# Altium schematic sheet p04_sensors.SchDoc
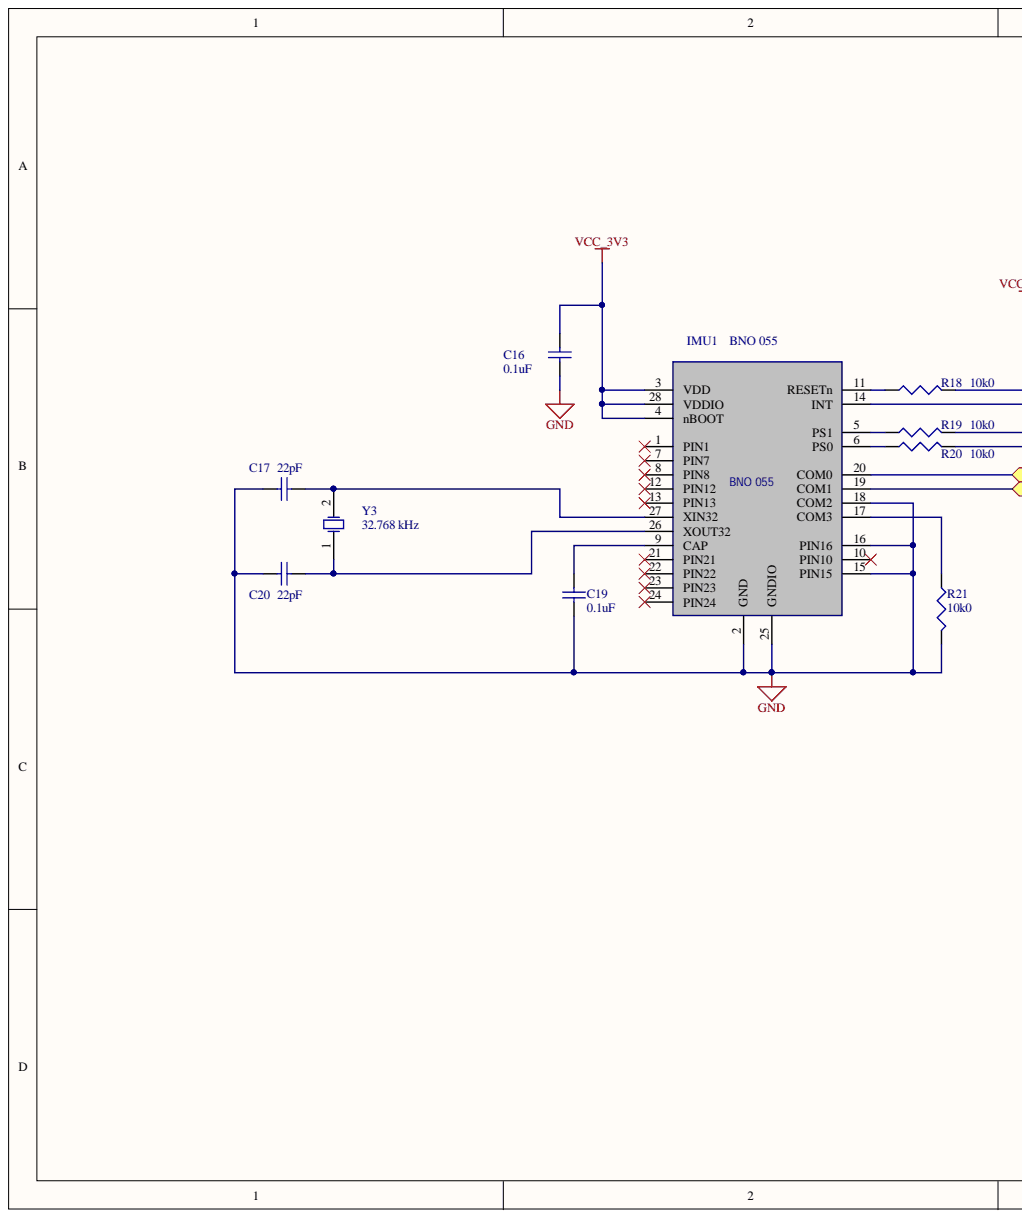
[diagram p0: p04_sensors.SchDoc, left]
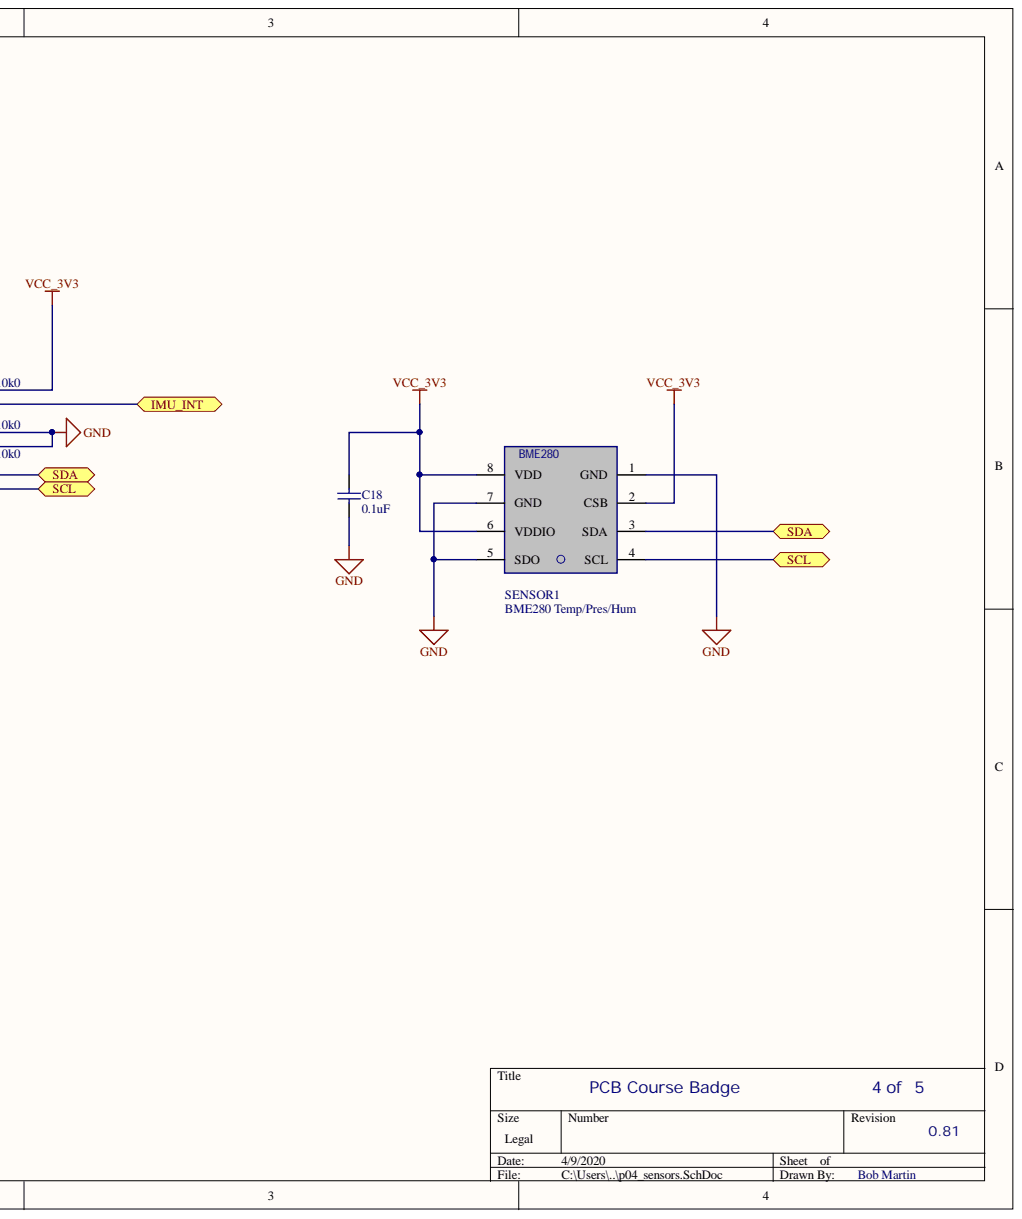
[diagram p1: p04_sensors.SchDoc, right]

## Parts
C16  value 0.1uF  [RAD-0.3]  (hidden comment: Cap)
C17  value 22pF  [RAD-0.3]  (hidden comment: Cap)
C18  value 0.1uF  [RAD-0.3]  (hidden comment: Cap)
C19  value 0.1uF  [RAD-0.3]  (hidden comment: Cap)
C20  value 22pF  [RAD-0.3]  (hidden comment: Cap)
IMU1  BNO 055
R18  value 10k0  [J1-0603]  (hidden comment: Res3)
R19  value 10k0  [J1-0603]  (hidden comment: Res3)
R20  value 10k0  [J1-0603]  (hidden comment: Res3)
R21  value 10k0  [J1-0603]  (hidden comment: Res3)
SENSOR1  BME280 Temp/Pres/Hum  value BME280
Y3  value 32.768 kHz  [R38]  (hidden comment: XTAL)

## Ports
IMU_INT, SCL, SDA

## Nets
GND: C16.1 C17.1 C18.2 C19.2 C20.1 IMU1.2(GND) IMU1.15(PIN15) IMU1.16(PIN16) IMU1.18(COM2) IMU1.25(GNDIO) R19.2 R20.2 R21.2 SENSOR1.1(GND) SENSOR1.5(SDO) SENSOR1.7(GND)
VCC_3V3: C16.2 C18.1 IMU1.3(VDD) IMU1.4(nBOOT) IMU1.28(VDDIO) R18.2 SENSOR1.2(CSB) SENSOR1.6(VDDIO) SENSOR1.8(VDD)
IMU_INT: IMU1.14(INT) ; port IMU_INT
SCL: IMU1.19(COM1) SENSOR1.4(SCL) ; port SCL
SDA: IMU1.20(COM0) SENSOR1.3(SDA) ; port SDA
NetC17_2: C17.2 IMU1.27(XIN32) Y3.2
NetC19_1: C19.1 IMU1.9(CAP)
NetC20_2: C20.2 IMU1.26(XOUT32) Y3.1
NetIMU1_5: IMU1.5(PS1) R19.1
NetIMU1_6: IMU1.6(PS0) R20.1
NetIMU1_11: IMU1.11(RESETn) R18.1
NetIMU1_17: IMU1.17(COM3) R21.1

Not connected: IMU1 1(PIN1) 7(PIN7) 8(PIN8) 10(PIN10) 12(PIN12) 13(PIN13) 21(PIN21) 22(PIN22) 23(PIN23) 24(PIN24)

## Library data of the parts
C16 C18 C19 = Cap: Capacitor; Manufacturer: KEMET; MPN: C0603C104Z3VACTU; Detail: CAP CER 0.1UF 25V Y5V 0603; Component Kind: Standard; Digi-Key PN: 399-1100-1-ND; Library Name: Miscellaneous Devices.IntLib; Signal Integrity: Cap; Simulation: CAP
C17 C20 = Cap: Capacitor; Manufacturer: KEMET; MPN: C0603C220J1GACTU; Detail: CAP CER 22PF 100V C0G/NP0 0603; Component Kind: Standard; Digi-Key PN: 399-7874-1-ND; Library Name: Miscellaneous Devices.IntLib; Signal Integrity: Cap; Simulation: CAP
IMU1 = BNO055_IMU: Manufacturer: Bosch Sensortec; MPN: BNO055; Detail: IMU ACCEL/GYRO/MAG I2C 28LGA; Component Kind: Standard; Digi-Key PN: 828-1058-1-ND; Library Name: badge1.SCHLIB
R18-R21 = Res3: Resistor; Manufacturer: Stackpole Electronics Inc; MPN: RNCP0603FTD10K0; Detail: RES 10K OHM 1% 1/8W 0603; Component Kind: Standard; Digi-Key PN: RNCP0603FTD10K0CT-ND; Library Name: Miscellaneous Devices.IntLib; Signal Integrity: Res; Simulation: RESISTOR
SENSOR1 = BME280: MEMS Temp/Pres/Hum sensor I2C; Manufacturer: Bosch Sensortec; Detail: SENSOR PRESSURE HUMIDITY TEMP; Component Kind: Standard; Digi-Key PN: 828-1063-1-ND; Library Name: badge1.SCHLIB; MPN: BME280
Y3 = XTAL: Crystal Oscillator; Manufacturer: EPSON; MPN: MC-405 32.7680K-E3: ROHS; Detail: CRYSTAL 32.7680 KHZ 6.0PF SMD; Component Kind: Standard; Digi-Key PN: SER4311TR-ND; Library Name: Miscellaneous Devices.IntLib; Simulation: XTAL
